# Altium schematic sheet MCU.SchDoc(MCU)
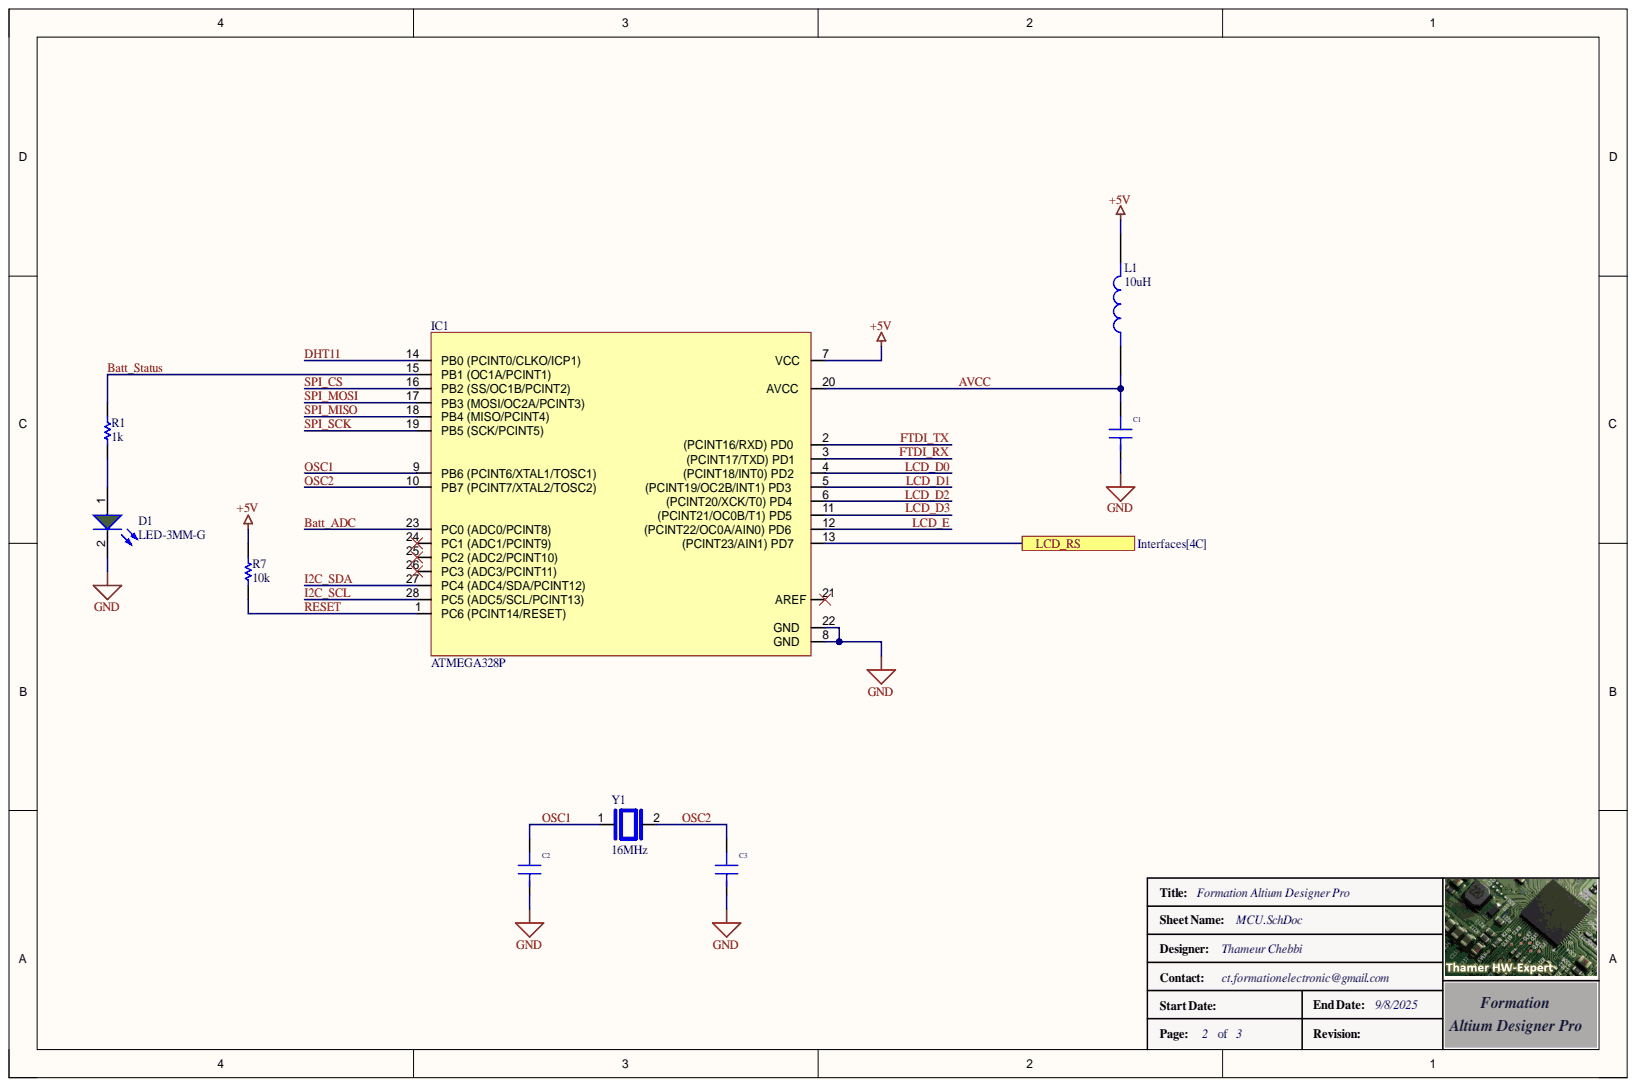
[diagram p0: MCU.SchDoc]

## Parts
C1  =Value  [C 0805(2012)x0.85]
C2  =Value  [C 0805(2012)x0.85]
C3  =Value  [C 0805(2012)x0.85]
D1  LED-3MM-G  [LED-2.54MM-G]
IC1  ATMEGA328P  [DIP28_P2.54mm_7.62mm]
L1  10uH  [IND_LQH31MN100J03L]
R1  1k  [RESC2013X50X30LL20T20]
R7  10k  [RESC2013X50X30LL20T20]
Y1  16MHz  [XTAL_HC49P488W43L1150T466H350]

## Ports
LCD_RS

## Nets
+5V: IC1.7(VCC) L1.2 R7.1
GND: C1.1 C2.1 C3.1 D1.2 IC1.8(GND) IC1.22(GND)
AVCC: C1.2 IC1.20(AVCC) L1.1
Batt_ADC: IC1.23(PC0_(ADC0/PCINT8))
Batt_Status: IC1.15(PB1_(OC1A/PCINT1)) R1.1
DHT11: IC1.14(PB0_(PCINT0/CLKO/ICP1))
FTDI_RX: IC1.3((PCINT17/TXD)_PD1)
FTDI_TX: IC1.2((PCINT16/RXD)_PD0)
I2C_SCL: IC1.28(PC5_(ADC5/SCL/PCINT13))
I2C_SDA: IC1.27(PC4_(ADC4/SDA/PCINT12))
LCD_D0: IC1.4((PCINT18/INT0)_PD2)
LCD_D1: IC1.5((PCINT19/OC2B/INT1)_PD3)
LCD_D2: IC1.6((PCINT20/XCK/T0)_PD4)
LCD_D3: IC1.11((PCINT21/OC0B/T1)_PD5)
LCD_E: IC1.12((PCINT22/OC0A/AIN0)_PD6)
LCD_RS: IC1.13((PCINT23/AIN1)_PD7) ; port LCD_RS
OSC1: C2.2 IC1.9(PB6_(PCINT6/XTAL1/TOSC1)) Y1.1
OSC2: C3.2 IC1.10(PB7_(PCINT7/XTAL2/TOSC2)) Y1.2
RESET: IC1.1(PC6_(PCINT14/RESET)) R7.2
SPI_CS: IC1.16(PB2_(SS/OC1B/PCINT2))
SPI_MISO: IC1.18(PB4_(MISO/PCINT4))
SPI_MOSI: IC1.17(PB3_(MOSI/OC2A/PCINT3))
SPI_SCK: IC1.19(PB5_(SCK/PCINT5))
NetD1_1: D1.1 R1.2

Not connected: IC1 21(AREF) 24(PC1_(ADC1/PCINT9)) 25(PC2_(ADC2/PCINT10)) 26(PC3_(ADC3/PCINT11))

## Library data of the parts
C1-C3 = C 0805    50V X7R - 0*: SMD 0805     50V X7R 10%; Manufacturer: Samsung; Package-mm: 2012; TYPE: Capacitors; Component Kind: Standard; ComponentLink1Description: Datasheet link; ComponentLink1URL: https://store.comet.bg/download-file.php?id=11; Mount Type: SMT; Package: 0805; Published: 07.04.2019; RoHS: Y; Supplier 1: Comet; Technology: Ceramic  MLCC; TempCo: X7R; Temperature Range: -55°C ~ +125°C; Tolerance %: ±10%; VDC: 50V; Library Name: SCH_THA.SchLib; Publisher: Hefest
IC1 = IC_ATMEGA328P_PDIP: Microcontroller, ATmega328P, 8-bit, PDIP-28 32kB Flash; Component Kind: Standard; Library Name: Symbol_Lib.SchLib
L1 = LQH31MN100J03L: Wire Wound Ferrite Inductor for General Circuits; MF: {'manufacturer': u'Murata'}; Availability: Unavailable; Component Kind: Standard; MP: LQH31MN100J03L; Package: None; Price: None; Library Name: SCH_THA.SchLib
R1 = RES-0805-SMD: Component Kind: Standard; Value: 1k; Library Name: SCH_THA.SchLib
R7 = RES-0805-SMD: Value: 1k; Component Kind: Standard; Library Name: SCH_THA.SchLib
Y1 = HC-49/U-S16000000ABJB: HC49US Series 16 MHz Â±30 ppm 18 pF -20 to +70 Â°C Through Hole AT-CUT Crystal; MF: {'manufacturer': u'Citizen Finedevice'}; Availability: Unavailable; Component Kind: Standard; MP: HC-49/U-S16000000ABJB; Package: None; Price: None; Library Name: SCH_THA.SchLib
D1 = LED-3MM-G: Component Kind: Standard; Library Name: SCH_THA.SchLib
